# Altium schematic sheet Sheet1.SchDoc(Sheet1)
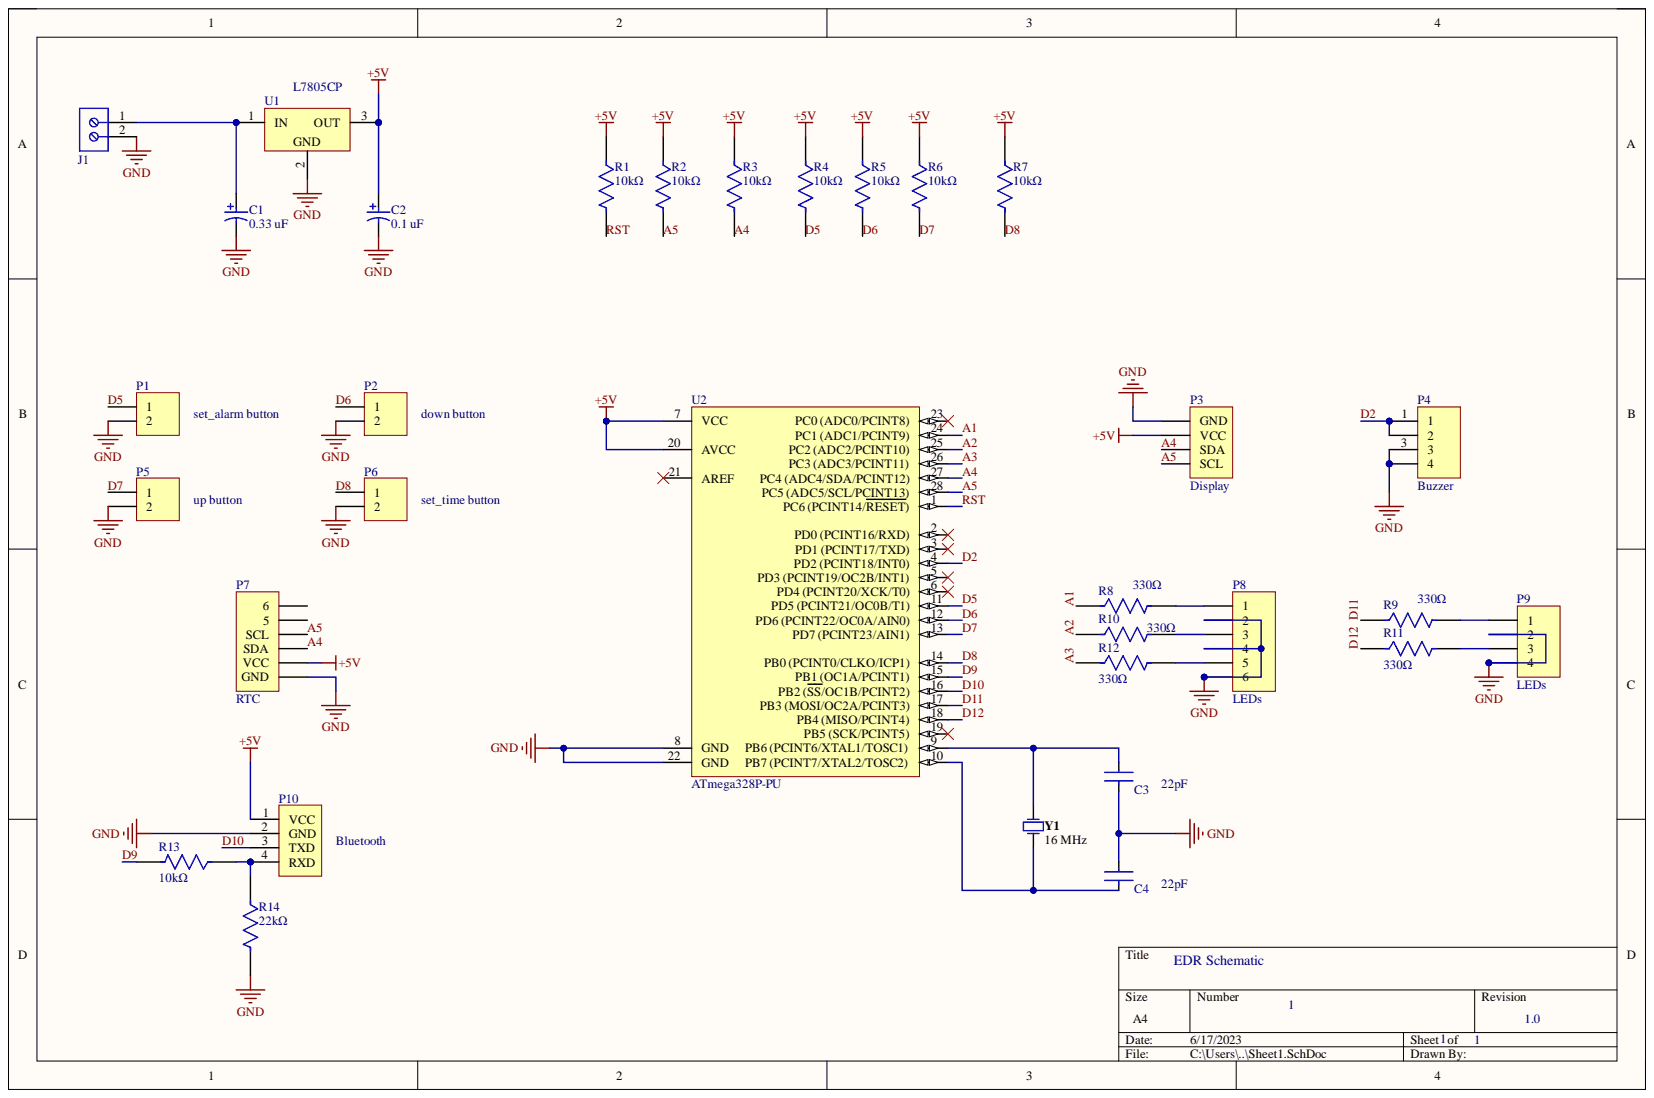
[diagram p0: Sheet1.SchDoc]

## Parts
C1  PLF1A471MDO1_big  [NIC-PLF-10x13-2_V]  (value not drawn)
C2  Rated Capacitance: 0.1 uF  [NIC-PLF-10x13-2_V]  (hidden comment: PLF1A471MDO1_big)
C3  RDE5C1H220J0K1H03B  [FP-RDE0M1-MFG]  (value not drawn)
C4  RDE5C1H220J0K1H03B  [FP-RDE0M1-MFG]  (value not drawn)
J1  691102710002  [691102710002]  (value not drawn)
P1  set_alarm button  [HDR1X2]
P2  down button  [HDR1X2]
P3  Display  [HDR1X4]
P4  Buzzer  [HDR1X4]
P5  up button  [HDR1X2]
P6  set_time button  [HDR1X2]
P7  RTC  [HDR1X6]
P8  LEDs  [HDR1X6]
P9  LEDs  [HDR1X4]
P10  Bluetooth  [HDR1X4]
R1  value 10kΩ  Resistance: 10kΩ  [FP-MFR-25-MFG]  (hidden comment: MFR-25FBF52-1K18)
R2  value 10kΩ  Resistance: 10kΩ  [FP-MFR-25-MFG]  (hidden comment: MFR-25FBF52-1K18)
R3  value 10kΩ  Resistance: 10kΩ  [FP-MFR-25-MFG]  (hidden comment: MFR-25FBF52-1K18)
R4  value 10kΩ  Resistance: 10kΩ  [FP-MFR-25-MFG]  (hidden comment: MFR-25FBF52-1K18)
R5  value 10kΩ  Resistance: 10kΩ  [FP-MFR-25-MFG]  (hidden comment: MFR-25FBF52-1K18)
R6  value 10kΩ  Resistance: 10kΩ  [FP-MFR-25-MFG]  (hidden comment: MFR-25FBF52-1K18)
R7  value 10kΩ  Resistance: 10kΩ  [FP-MFR-25-MFG]  (hidden comment: MFR-25FBF52-1K18)
R8  value 330Ω  Resistance: 330Ω  [FP-MFR-25-MFG]  (hidden comment: MFR-25FBF52-1K18)
R9  value 330Ω  Resistance: 330Ω  [FP-MFR-25-MFG]  (hidden comment: MFR-25FBF52-1K18)
R10  value 330Ω  Resistance: 330Ω  [FP-MFR-25-MFG]  (hidden comment: MFR-25FBF52-1K18)
R11  value 330Ω  Resistance: 330Ω  [FP-MFR-25-MFG]  (hidden comment: MFR-25FBF52-1K18)
R12  value 330Ω  Resistance: 330Ω  [FP-MFR-25-MFG]  (hidden comment: MFR-25FBF52-1K18)
R13  value 10kΩ  Resistance: 10kΩ  [FP-MFR-25-MFG]  (hidden comment: MFR-25FBF52-1K18)
R14  value 22kΩ  Resistance: 22kΩ  [FP-MFR-25-MFG]  (hidden comment: MFR-25FBF52-1K18)
U1  L7805CP  [TO220FP]
U2  ATmega328P-PU  [28P3]
Y1  16 MHz  [FOX-FOXSLF160-20]

## Nets
+5V: C2.1 P3.2(VCC) P7.2(VCC) P10.1(VCC) R1.1 R2.1 R3.1 R4.1 R5.1 R6.1 R7.1 U1.3 U2.7(VCC) U2.20(AVCC)
GND: C1.2 C2.2 C3.1 C4.2 J1.2 P1.2 P2.2 P3.1(GND) P4.3 P4.4 P5.2 P6.2 P7.1(GND) P8.2 P8.4 P8.6 P9.2 P9.4 P10.2(GND) R14.2 U1.2 U2.8(GND) U2.22(GND)
A1: R8.1 U2.24(PC1_(ADC1/PCINT9))
A2: R10.1 U2.25
A3: R12.1 U2.26(PC3_(ADC3/PCINT11))
A4: P3.3(SDA) P7.3(SDA) R3.2 U2.27
A5: P3.4(SCL) P7.4(SCL) R2.2 U2.28(PC5_(ADC5/SCL/PCINT13))
D2: P4.1 P4.2 U2.4(PD2_(PCINT18/INT0))
D5: P1.1 R4.2 U2.11(PD5_(PCINT21/OC0B/T1))
D6: P2.1 R5.2 U2.12(PD6_(PCINT22/OC0A/AIN0))
D7: P5.1 R6.2 U2.13(PD7_(PCINT23/AIN1))
D8: P6.1 R7.2 U2.14(PB0_(PCINT0/CLKO/ICP1))
D9: R13.2 U2.15(PB1_(OC1A/PCINT1))
D10: P10.3(TXD) U2.16(PB2_(SS/OC1B/PCINT2))
D11: R9.1 U2.17(PB3_(MOSI/OC2A/PCINT3))
D12: R11.1 U2.18(PB4_(MISO/PCINT4))
RST: R1.2 U2.1(PC6_(PCINT14/RESET))
NetC1_1: C1.1 J1.1 U1.1
NetC3_2: C3.2 U2.9 Y1.1
NetC4_1: C4.1 U2.10 Y1.2
NetP8_1: P8.1 R8.2
NetP8_3: P8.3 R10.2
NetP8_5: P8.5 R12.2
NetP9_1: P9.1 R9.2
NetP9_3: P9.3 R11.2
NetP10_4: P10.4(RXD) R13.1 R14.1

Not connected: P7 5 6; U2 2(PD0_(PCINT16/RXD)) 3(PD1_(PCINT17/TXD)) 5(PD3_(PCINT19/OC2B/INT1)) 6(PD4_(PCINT20/XCK/T0)) 19(PB5_(SCK/PCINT5)) 21(AREF) 23(PC0_(ADC0/PCINT8))

## Library data of the parts
C1 = CMP-1234-00001-1: Aluminum Electrolytic Capacitor, 470 uF +/-20%, 10 V, -55 to 105 degC, 2-Pin THD, RoHS; Manufacturer: Nichicon; Leakage Current: 0.94 mA; Rated Capacitance: 0.33 uF; Rated Ripple: 5700 mA; Rated Voltage: 10 V; Capacitance Tolerance: +/-20%; Component Kind: Standard; ComponentLink1Description: Manufacturer URL; ComponentLink1URL: http://www.nichicon.co.jp/; ComponentLink2Description: Datasheet; ComponentLink2URL: http://nichicon-us.com/english/products/pdfs/e-lf.pdf; ComponentLink3Description: Package Specification; ComponentLink3URL: http://nichicon-us.com/english/products/pdfs/e-lf.pdf; DatasheetVersion: Sep-13; Library Name: Altium Content Vault; Mounting Technology: Through Hole; PackageDescription: 2-Pin Through Hole Device, Dia 10 mm, Body Length 13 mm, Pin Spacing 5 mm; PartNumber: PLF1A471MDO1; RoHS: TRUE; Temperature Range: -55 to 105 degC
C2 = CMP-1234-00001-1: Aluminum Electrolytic Capacitor, 470 uF +/-20%, 10 V, -55 to 105 degC, 2-Pin THD, RoHS; Manufacturer: Nichicon; Leakage Current: 0.94 mA; Rated Ripple: 5700 mA; Rated Voltage: 10 V; Capacitance Tolerance: +/-20%; Component Kind: Standard; ComponentLink1Description: Manufacturer URL; ComponentLink1URL: http://www.nichicon.co.jp/; ComponentLink2Description: Datasheet; ComponentLink2URL: http://nichicon-us.com/english/products/pdfs/e-lf.pdf; ComponentLink3Description: Package Specification; ComponentLink3URL: http://nichicon-us.com/english/products/pdfs/e-lf.pdf; DatasheetVersion: Sep-13; Library Name: Altium Content Vault; Mounting Technology: Through Hole; PackageDescription: 2-Pin Through Hole Device, Dia 10 mm, Body Length 13 mm, Pin Spacing 5 mm; PartNumber: PLF1A471MDO1; RoHS: TRUE; Temperature Range: -55 to 105 degC
C3 C4 = CMP-06035-055552-1: Ceramic Capacitor 22pF ±5% 50V C0G Radial; Lead Diameter: 0.5mm; Capacitance: 22pF; Case/Package: Radial; Component Kind: Standard; Dielectric: C0G; Height: 3.5mm; Height - Seated (Max): 0.236inch; Lead Free: Lead Free; Lead Pitch: 0.197inch; Length: 0.157inch; Library Name: Altium Content Vault; Max Operating Temperature: 125°C; Min Operating Temperature: -55°C; Mount: Through Hole; Package Quantity: 500; Packaging: Bulk; Resistor Type: Ceramic; Termination: Radial; Tolerance: 5%; Voltage Rating: 50V; Voltage Rating (DC): 50V; Width: 0.098inch
J1 = CMP-1502-03258-1: Series 102 - 5.00 mm Horizontal Entry Modular with Pressure Clamp WR-TBL, 2 pin; Manufacturer: Wurth Elektronik; Pin Number: 2; Pitch: 5.00mm; Component Kind: Standard; Datasheet URL: http://katalog.we-online.de/em/datasheet/6911027100xx.pdf; Library Name: Altium Content Vault; Manufacturer URL: http://www.we-online.de; Mounting Technology: THT; Operating Temperature: -40to105degC; Package Reference: 691102710002; Packaging: Box; Part Number: 691102710002; Publisher: WurthElektronik
P1 P2 P5 P6 = Header 2: Header, 2-Pin; Component Kind: Standard; LatestRevisionDate: 17-Jul-2002; LatestRevisionNote: Re-released for DXP Platform.; Library Name: Miscellaneous Connectors.IntLib; Signal Integrity: Connector; Publisher: Altium Limited
P3 P4 P9 P10 = Header 4: Header, 4-Pin; Component Kind: Standard; LatestRevisionDate: 17-Jul-2002; LatestRevisionNote: Re-released for DXP Platform.; Library Name: Miscellaneous Connectors.IntLib; Signal Integrity: Connector; Publisher: Altium Limited
P7 P8 = Header 6: Header, 6-Pin; Component Kind: Standard; LatestRevisionDate: 17-Jul-2002; LatestRevisionNote: Re-released for DXP Platform.; Library Name: Miscellaneous Connectors.IntLib; Signal Integrity: Connector; Publisher: Altium Limited
R1-R14 = CMP-03413-000001-1: RES 1.18K OHM 1/4W 1% AXIAL; Manufacturer: Yageo; Power Rating: 0.25W; Temperature Coefficient: 100ppm/°C; Voltage Rating: 250V; Automotive: No; Category: Res; Component Kind: Standard; ComponentLink2Description: Datasheet; ComponentLink2URL: https://www.yageo.com/upload/media/product/products/datasheet/lr/Yageo_LR_MFR_1.pdf; Composition: Metal Film; Device Class L1: Passive Components; Device Class L2: Resistors; Device Class L3: Through-Hole Resistors; Digikey Description: RES 1.18K OHM 1/4W 1% AXIAL; Height: 2.6mm; Ipc Land Pattern Name: RESAD1000W75L680D260; Lead Free: Yes; Library Name: Altium Content Vault; Manufacturer Part Number: MFR-25FBF52-1K18; Mouser Description: Metal Film Resistors 1.18K OHM 1/4W 1%; Octopart: https://octopart.com/mfr-25fbf52-1k18-yageo-56251681; Package: MFR-25; RoHS: TRUE; Series: MFR; Temperature: -55°C to +155°C; Temperature Range High: +155°C; Temperature Range Low: -55°C; Tolerance: 1%
U1 = CMP-0244-00474-1: Positive Voltage Regulator, 5V, 3-Pin TO-220FP; Component Kind: Standard; ComponentLink1Description: Manufacturer URL; ComponentLink1URL: http://www.st.com; ComponentLink2Description: Datasheet; ComponentLink2URL: http://www.st.com/internet/com/TECHNICAL_RESOURCES/TECHNICAL_LITERATURE/DATASHEET/CD00000444.pdf; DatasheetVersion: 16/11/2011; Library Name: Altium Content Vault; PackageDescription: TO, Thru-Hole, Vertical, Heatsink Mounted; 3 In-Line Leads; Pitch 2.54 mm; PackageReference: TO220FP; PackageVersion: 2011
U2 = CMP-0095-00013-2: 8-bit AVR Microcontroller, 32KB Flash, 1KB EEPROM, 2KB SRAM, 28-pin PDIP, Industrial Grade (-40°C to 85°C); Component Kind: Standard; ComponentLink1Description: Manufacturer URL; ComponentLink1URL: http://www.atmel.com/; ComponentLink2Description: ATmega48A/48PA/88A/88PA/168A/168PA/328/328P Datasheet; ComponentLink2URL: http://www.atmel.com/dyn/resources/prod_documents/8271.pdf; ComponentLink3Description: ATmega48A/48PA/88A/88PA/168A/168PA/328/328P Summary; ComponentLink3URL: http://www.atmel.com/dyn/resources/prod_documents/8271S.pdf; DatasheetVersion: revD, May-2011; Library Name: Altium Content Vault; PackageDescription: 28-lead (0.300"/7.62mm Wide) Plastic Dual Inline Package (PDIP); PackageReference: 28P3; PackageVersion: revB, Sep-2001; RevisionNote: DatasheetVersion updated. URLs added.
Y1 = CMP-1749-00003-1: Resistance Weld Thru-Hole Crystal, 16 MHz, -20 to 70 degC, 2-Pin THD, RoHS, Bulk; Case\Package: Axial; Power Rating: 250 mW; Resistance: 221 kohm; Tolerance: 1%; Voltage Rating: 250 V; Component Kind: Standard; ComponentLink1Description: Manufacturer URL; ComponentLink1URL: http://www.foxonline.com/; ComponentLink2Description: Datasheet; ComponentLink2URL: http://www.foxonline.com/pdfs/hc49slf.pdf; DatasheetVersion: Mar-15; Length: 0.248 inch; Library Name: Altium Content Vault; Max Operating Temperature: 155 degC; Min Operating Temperature: -55 degC; Mount: Through Hole; Package Quantity: 200; PackageDescription: 2-Pin Through Hole Device, Body 11.35 x 4.65 mm; PackageReference: FOXSLF160-20; Packaging: Bulk; RoHSCompliant: true
